# Altium schematic sheet Main_MCU.SchDoc
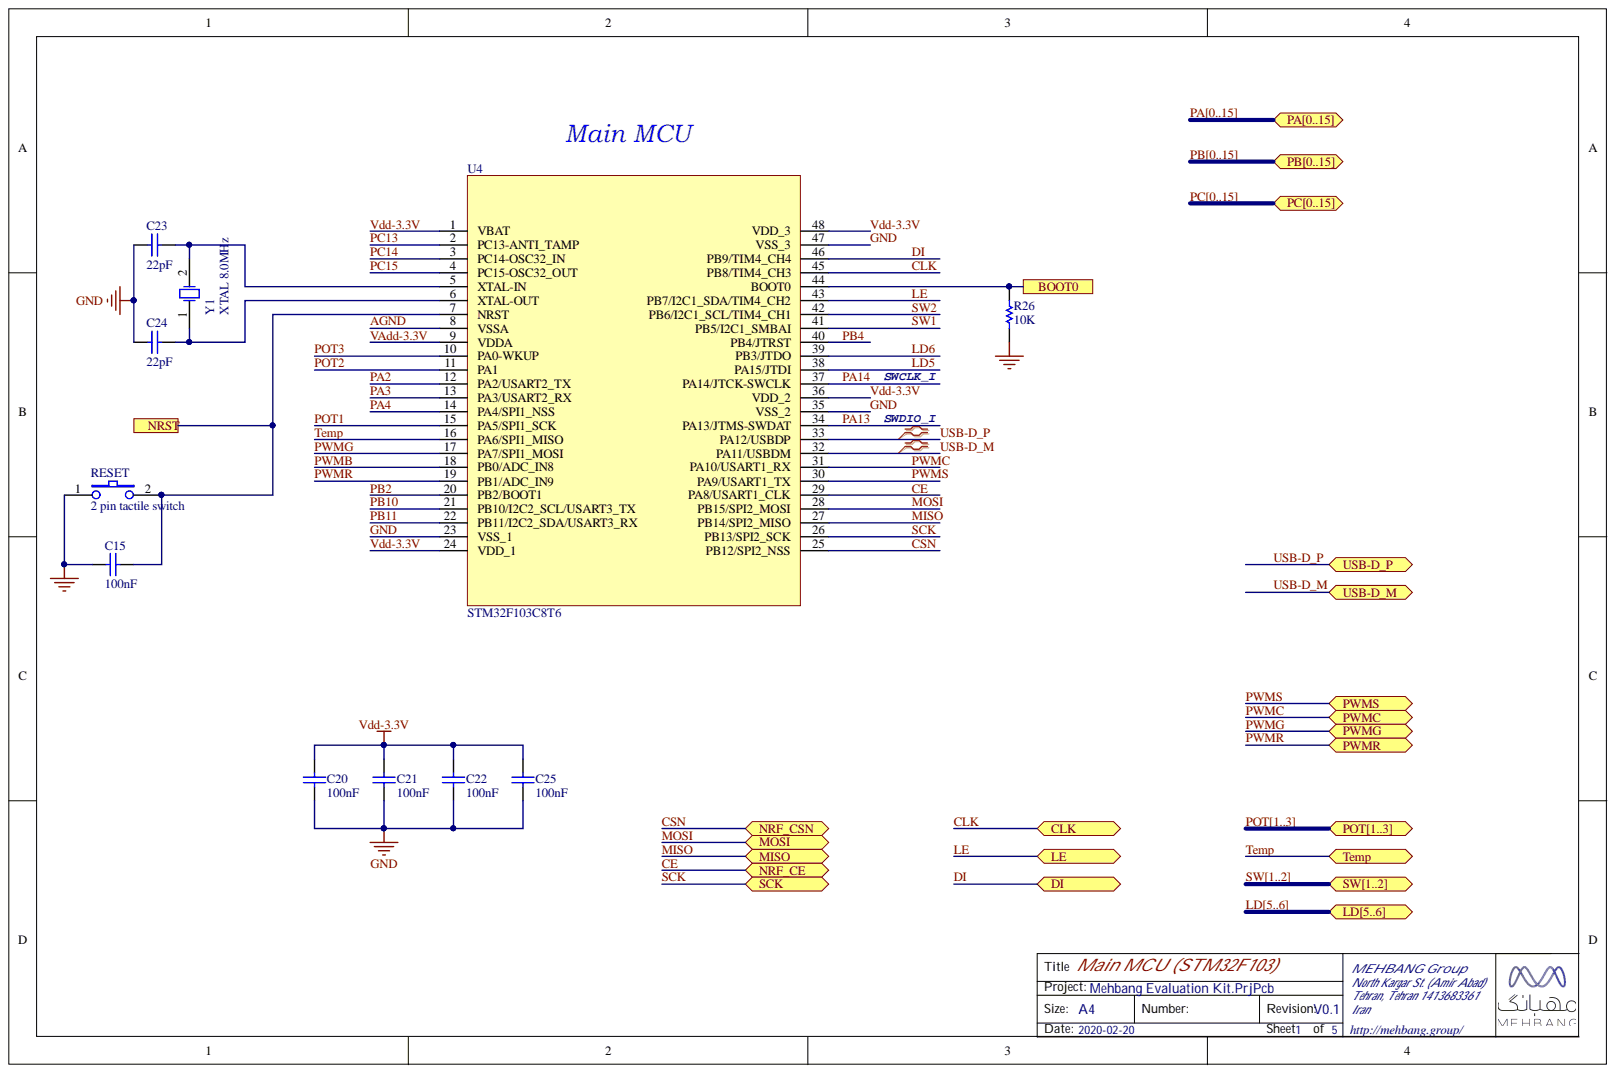
[diagram p0: Main_MCU.SchDoc]

## Parts
C15  100nF  [0805-Cap]
C20  100nF  [0805-Cap]
C21  100nF  [0805-Cap]
C22  100nF  [0805-Cap]
C23  22pF  [0805-Cap]
C24  22pF  [0805-Cap]
C25  100nF  [0805-Cap]
R26  10K  [0805-Res]
RESET  2 pin tactile switch  [PB-6X6mm-dip-2pin-Black]
U4  STM32F103C8T6  [PCBComponent_1]
Y1  XTAL 8.0MHz  [XTAL-SMD]

## Ports
BOOT0, CLK, DI, LD5, LD6, LD[5..6], LE, MISO, MOSI, NRF_CE, NRF_CSN, NRST, PA0, PA1, PA2, PA3, PA4, PA5, PA6, PA7, PA8, PA9, PA10, PA11, PA12, PA13, PA14, PA15, PA[0..15], PB0, PB1, PB2, PB3, PB4, PB5, PB6, PB7, PB8, PB9, PB10, PB11, PB12, PB13, PB14, PB15, PB[0..15], PC0, PC1, PC2, PC3, PC4, PC5, PC6, PC7, PC8, PC9, PC10, PC11, PC12, PC13, PC14, PC15, PC[0..15], POT1, POT2, POT3, POT[1..3], PWMC, PWMG, PWMR, PWMS, SCK, SW1, SW2, SW[1..2], Temp, USB-D_M, USB-D_P

## Nets
AGND: U4.8(VSSA)
BOOT0: R26.1 U4.44(BOOT0) ; port BOOT0
CE: U4.29(PA8/USART1_CLK) ; port NRF_CE
CLK: U4.45(PB8/TIM4_CH3) ; port CLK
CSN: U4.25(PB12/SPI2_NSS) ; port NRF_CSN
DI: U4.46(PB9/TIM4_CH4) ; port DI
GND: C15.2 C20.2 C21.2 C22.2 C23.2 C24.2 C25.2 R26.2 RESET.1 U4.23(VSS_1) U4.35(VSS_2) U4.47(VSS_3)
LD5: U4.38(PA15/JTDI) ; port LD[5..6]  [also labelled LD]
LD6: U4.39(PB3/JTDO) ; port LD[5..6]  [also labelled LD]
LE: U4.43(PB7/I2C1_SDA/TIM4_CH2) ; port LE
MISO: U4.27(PB14/SPI2_MISO) ; port MISO
MOSI: U4.28(PB15/SPI2_MOSI) ; port MOSI
NRST: C15.1 RESET.2 U4.7(NRST) ; port NRST
PA2: U4.12(PA2/USART2_TX) ; port PA[0..15]  [also labelled PA]
PA3: U4.13(PA3/USART2_RX) ; port PA[0..15]  [also labelled PA]
PA4: U4.14(PA4/SPI1_NSS) ; port PA[0..15]  [also labelled PA]
PA13: U4.34(PA13/JTMS-SWDAT) ; port PA[0..15]  [also labelled PA]
PA14: U4.37(PA14/JTCK-SWCLK) ; port PA[0..15]  [also labelled PA]
PB2: U4.20(PB2/BOOT1) ; port PB[0..15]  [also labelled PB]
PB4: U4.40(PB4/JTRST) ; port PB[0..15]  [also labelled PB]
PB10: U4.21(PB10/I2C2_SCL/USART3_TX) ; port PB[0..15]  [also labelled PB]
PB11: U4.22(PB11/I2C2_SDA/USART3_RX) ; port PB[0..15]  [also labelled PB]
PC13: U4.2(PC13-ANTI_TAMP) ; port PC[0..15]  [also labelled PC]
PC14: U4.3(PC14-OSC32_IN) ; port PC[0..15]  [also labelled PC]
PC15: U4.4(PC15-OSC32_OUT) ; port PC[0..15]  [also labelled PC]
POT1: U4.15(PA5/SPI1_SCK) ; port POT[1..3]  [also labelled POT]
POT2: U4.11(PA1) ; port POT[1..3]  [also labelled POT]
POT3: U4.10(PA0-WKUP) ; port POT[1..3]  [also labelled POT]
PWMB: U4.18(PB0/ADC_IN8)
PWMC: U4.31(PA10/USART1_RX) ; port PWMC
PWMG: U4.17(PA7/SPI1_MOSI) ; port PWMG
PWMR: U4.19(PB1/ADC_IN9) ; port PWMR
PWMS: U4.30(PA9/USART1_TX) ; port PWMS
SCK: U4.26(PB13/SPI2_SCK) ; port SCK
SW1: U4.41(PB5/I2C1_SMBAI) ; port SW[1..2]  [also labelled SW]
SW2: U4.42(PB6/I2C1_SCL/TIM4_CH1) ; port SW[1..2]  [also labelled SW]
Temp: U4.16(PA6/SPI1_MISO) ; port Temp
USB-D_M: U4.32(PA11/USBDM) ; port USB-D_M
USB-D_P: U4.33(PA12/USBDP) ; port USB-D_P
VAdd-3.3V: U4.9(VDDA)
Vdd-3.3V: C20.1 C21.1 C22.1 C25.1 U4.1(VBAT) U4.24(VDD_1) U4.36(VDD_2) U4.48(VDD_3)
NetC23_1: C23.1 U4.5(XTAL-IN) Y1.2
NetC24_1: C24.1 U4.6(XTAL-OUT) Y1.1
PA0: ; port PA[0..15]  [also labelled PA]
PA1: ; port PA[0..15]  [also labelled PA]
PA5: ; port PA[0..15]  [also labelled PA]
PA6: ; port PA[0..15]  [also labelled PA]
PA7: ; port PA[0..15]  [also labelled PA]
PA8: ; port PA[0..15]  [also labelled PA]
PA9: ; port PA[0..15]  [also labelled PA]
PA10: ; port PA[0..15]  [also labelled PA]
PA11: ; port PA[0..15]  [also labelled PA]
PA12: ; port PA[0..15]  [also labelled PA]
PA15: ; port PA[0..15]  [also labelled PA]
PB0: ; port PB[0..15]  [also labelled PB]
PB1: ; port PB[0..15]  [also labelled PB]
PB3: ; port PB[0..15]  [also labelled PB]
PB5: ; port PB[0..15]  [also labelled PB]
PB6: ; port PB[0..15]  [also labelled PB]
PB7: ; port PB[0..15]  [also labelled PB]
PB8: ; port PB[0..15]  [also labelled PB]
PB9: ; port PB[0..15]  [also labelled PB]
PB12: ; port PB[0..15]  [also labelled PB]
PB13: ; port PB[0..15]  [also labelled PB]
PB14: ; port PB[0..15]  [also labelled PB]
PB15: ; port PB[0..15]  [also labelled PB]
PC0: ; port PC[0..15]  [also labelled PC]
PC1: ; port PC[0..15]  [also labelled PC]
PC2: ; port PC[0..15]  [also labelled PC]
PC3: ; port PC[0..15]  [also labelled PC]
PC4: ; port PC[0..15]  [also labelled PC]
PC5: ; port PC[0..15]  [also labelled PC]
PC6: ; port PC[0..15]  [also labelled PC]
PC7: ; port PC[0..15]  [also labelled PC]
PC8: ; port PC[0..15]  [also labelled PC]
PC9: ; port PC[0..15]  [also labelled PC]
PC10: ; port PC[0..15]  [also labelled PC]
PC11: ; port PC[0..15]  [also labelled PC]
PC12: ; port PC[0..15]  [also labelled PC]

## Library data of the parts
C15 C20-C25 = Cap: Capacitor; Component Kind: Standard; LatestRevisionDate: 17-Jul-2002; LatestRevisionNote: Re-released for DXP Platform.; Library Name: DaEvKit-LIB.IntLib; PackageDescription: Radial Cap, Thru-Hole; 2 Leads; 0.3 in Pin Spacing; PackageReference: RAD-0.3; Published: 8-Jun-2000; Publisher: Altium Limited
R26 = Res: Resistor; Code_IPC: 2012 [0805]; Component Kind: Standard; ComponentLink1Description: Manufacturer Link; ComponentLink1URL: http://www.panasonic.com/industrial/components/resistive_products.htm; ComponentLink2Description: Datasheet; ComponentLink2URL: http://www.panasonic.com/industrial/components/pdf/AOF0000CE1.pdf; LatestRevisionNote: Silkscreen size reduced.; Library Name: DaEvKit-LIB.IntLib; Publisher: Altium Limited
RESET = PUSHBUTTON 2 pin: Component Kind: Standard; Description: 2 pin tactile switch; Library Name: DaEvKit-LIB.IntLib
U4 = STM32F103C8T6: Mainstream Performance line, ARM Cortex-M3 MCU with 64 Kbytes Flash, 72 MHz CPU, motor control, USB and CAN; Component Kind: Standard; Library Name: DaEvKit-LIB.IntLib
Y1 = XTAL: Crystal Oscillator; Component Kind: Standard; LatestRevisionDate: 17-Jul-2002; LatestRevisionNote: Re-released for DXP Platform.; Library Name: DaEvKit-LIB.IntLib; PackageDescription: Crystal, Thru-Hole; 2 Leads; Body 3.1 x 8.2 mm (Dia.xH); PackageReference: R38; Published: 8-Jun-2000; Publisher: Altium Limited
